# Altium schematic sheet Power.SchDoc(Power)
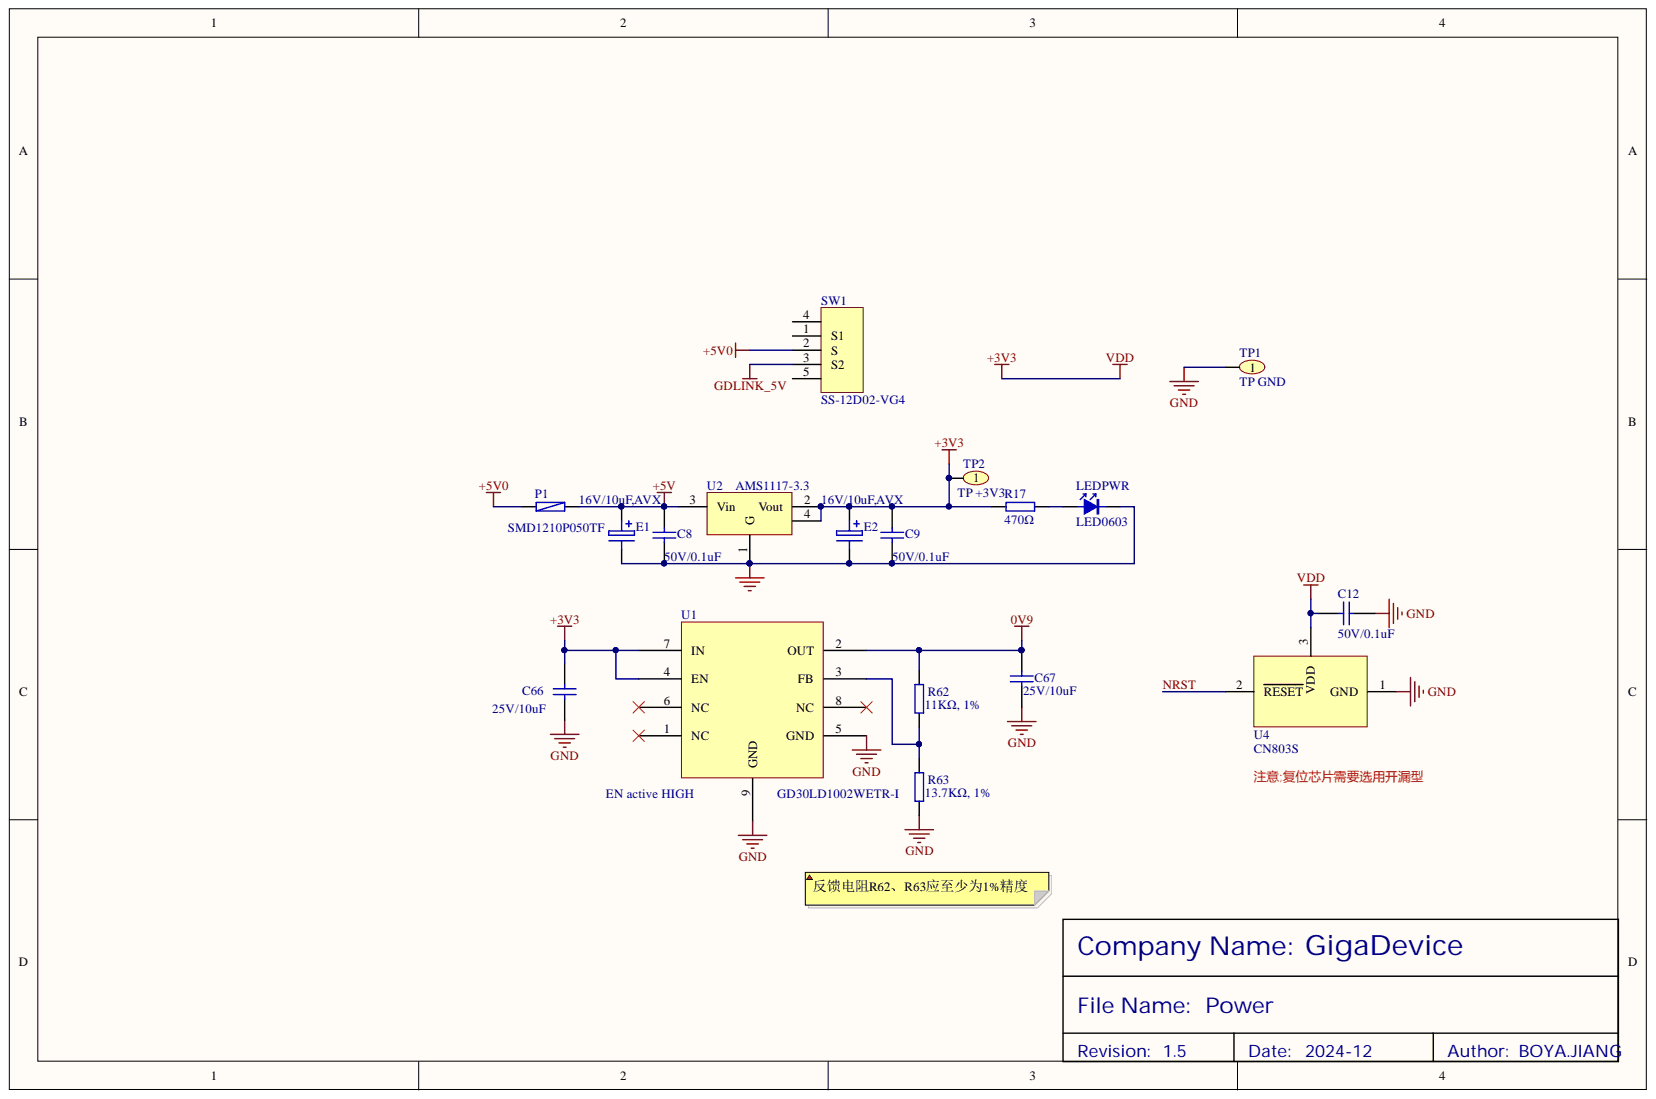
[diagram p0: Power.SchDoc]

## Parts
C8  50V/0.1uF  [0603-C]
C9  50V/0.1uF  [0603-C]
C12  50V/0.1uF  [0603-C]
C66  25V/10uF  [0805-C]
C67  25V/10uF  [0805-C]
E1  16V/10uF,AVX  [SM/C-A-3216]
E2  16V/10uF,AVX  [SM/C-A-3216]
LEDPWR  LED0603  [0603-LED-Green]
P1  SMD1210P050TF  [1210_R]
R17  470Ω  [0603-R]
R62  11KΩ, 1%  [0603-R]
R63  13.7KΩ, 1%  [0603-R]
SW1  SS-12D02-VG4  [SW-SPDT]
TP1  TP GND  [SIP-1]
TP2  TP +3V3  [SIP-1]
U1  GD30LD1002WETR-I  [DFN-8(3X3)]
U2  AMS1117-3.3  [SOT-223]
U4  CN803S  [SOT-23]

## Nets
0V9: C67.2 R62.2 U1.2(OUT)
+3V3: C9.2 C12.2 C66.2 E2.1 R17.1 TP2.1 U1.4(EN) U1.7(IN) U2.2(Vout) U2.4 U4.3
+5V: C8.2 E1.1 P1.2 U2.3(Vin)
+5V0: P1.1 SW1.2(S)
GDLINK_5V: SW1.3(S2)
GND: C8.1 C9.1 C12.1 C66.1 C67.1 E1.2 E2.2 LEDPWR.K R63.1 TP1.1 U1.5(GND) U1.9(GND) U2.1(G) U4.1
NRST: U4.2
NetLEDPWR_A: LEDPWR.A R17.2
NetR62_1: R62.1 R63.2 U1.3(FB)

Not connected: SW1 1(S1) 4 5; U1 1(NC) 6(NC) 8(NC)

## Library data of the parts
C8 C9 C12 C66 C67 = 50V/0.1uF: 表贴电容; Component Kind: Standard; Library Name: KLTC-电容.SchLib
E1 E2 = 16V/10uF,AVX: 表贴钽电容; Component Kind: Standard; Library Name: KLTC-电容.SchLib
LEDPWR = LED0603: 表贴LED发光管; Component Kind: Standard; Library Name: xufei.SchLib
P1 = SMD1210P005TF: 表贴PTC; Component Kind: Standard; Library Name: KLTC-保护元件.SchLib
R17 = 470Ω: 表贴电阻; Component Kind: Standard; Library Name: KLTC-电阻.SchLib
R62 R63 = 0Ω: 表贴电阻; Component Kind: Standard; Library Name: KLTC-电阻.SchLib
SW1 = SW-SPDT: 直插2位选择开关; Component Kind: Standard; Library Name: xufei.SchLib
TP1 TP2 = HEADER 1: 接点; Component Kind: Standard; Library Name: KLTC-按键及接插件.SchLib
U1 = GD30LD1002: 表贴0.9V LDO; Library Name: GD-Power.SchLib; Component Kind: Standard
U2 = LM1117-3.3: 表贴3.3V LDO; Component Kind: Standard; Library Name: xufei.SchLib
U4 = CS803S: 表贴电源监控复位芯片; Database Table Name: GD-Switch; Value: ?; Library Name: SVNDatabase.SVNDbLib; ID: CS803S; Component Kind: Standard
